# Altium schematic sheet Display.SchDoc(Display)
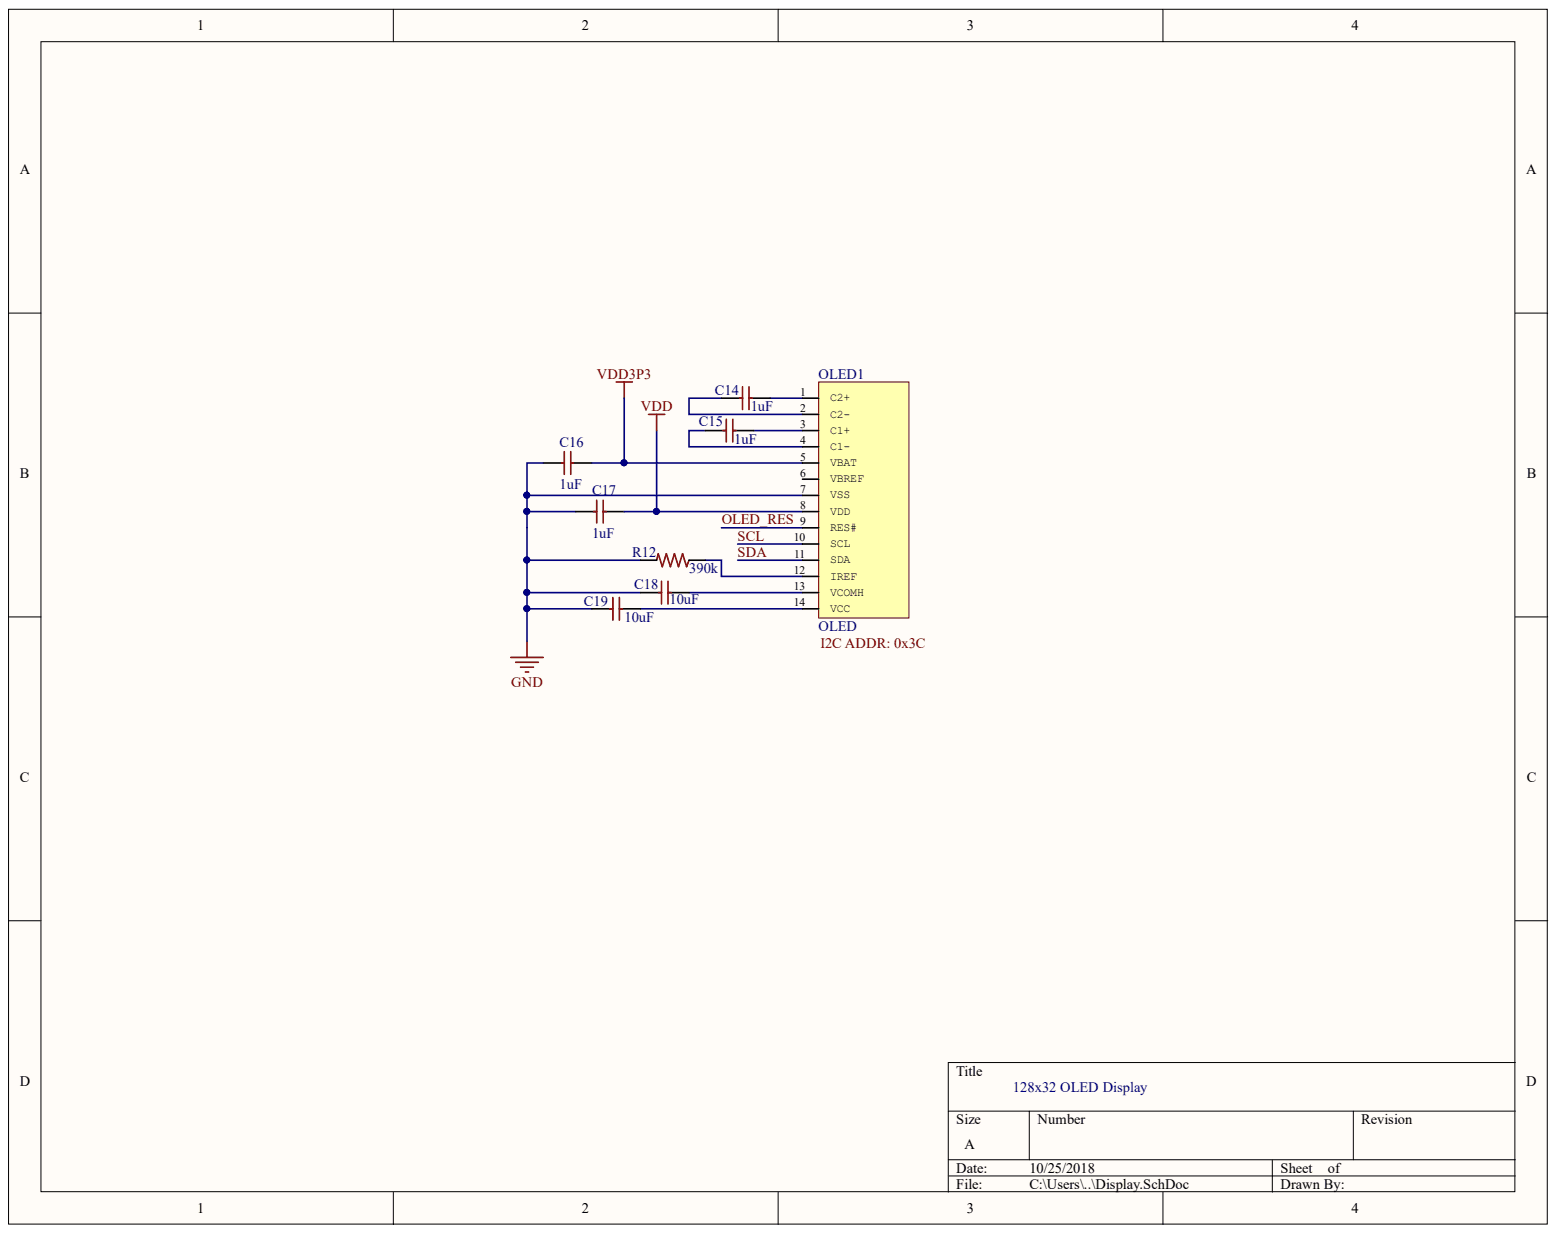
[diagram p0: Display.SchDoc]

## Parts
C14  1uF  [CAP0603]
C15  1uF  [CAP0603]
C16  1uF  [CAP0603]
C17  1uF  [CAP0603]
C18  10uF  [CAP0603]
C19  10uF  [CAP0603]
OLED1  OLED  [OLED Top]
R12  390k  [RES0603]

## Nets
GND: C16.2 C17.2 C18.2 C19.2 OLED1.7(VSS) R12.2
VDD: C17.1 OLED1.8(VDD)
VDD3P3: C16.1 OLED1.5(VBAT)
OLED_RES: OLED1.9(RES#)
SCL: OLED1.10(SCL)
SDA: OLED1.11(SDA)
NetC14_1: C14.1 OLED1.1(C2+)
NetC14_2: C14.2 OLED1.2(C2-)
NetC15_1: C15.1 OLED1.3(C1+)
NetC15_2: C15.2 OLED1.4(C1-)
NetC18_1: C18.1 OLED1.13(VCOMH)
NetC19_1: C19.1 OLED1.14(VCC)
NetOLED1_12: OLED1.12(IREF) R12.1

Not connected: OLED1 6(VBREF)

## Library data of the parts
C14-C19 = Capacitor: Component Kind: Standard; Library Name: karo_components.IntLib
R12 = Resistor: Component Kind: Standard; Library Name: karo_components.IntLib
OLED1 = OLED: Component Kind: Standard; Library Name: karo_components.IntLib
